# Altium schematic sheet RS232.SchDoc
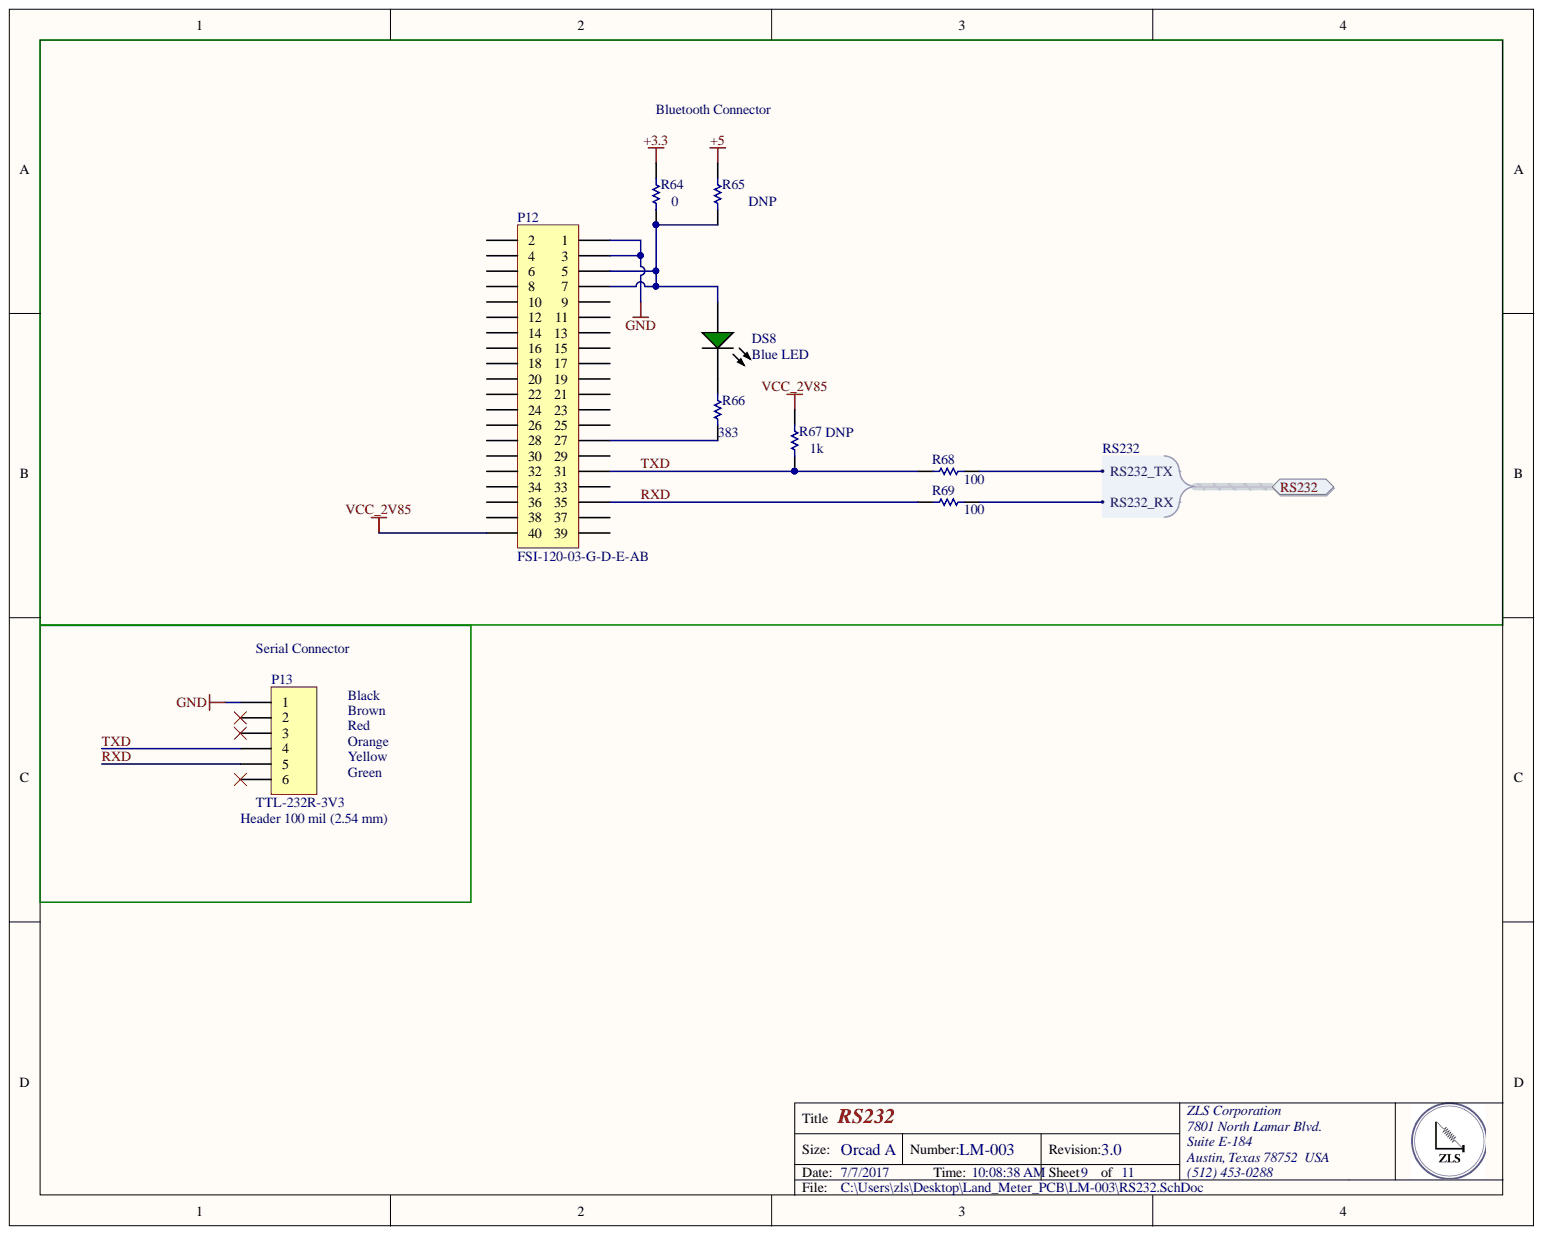
[diagram p0: RS232.SchDoc]

## Parts
DS8  Blue LED  Color: Blue  [KING-LED0603-25-GREEN_V]
P12  FSI-120-03-G-D-E-AB  [FSI-120-03-G-D-E-AB]
P13  Header 100 mil (2.54 mm)  [HDR1X6]
R64  RES SMD 0.0 OHM JUMPER 1/8W 0805  [RESC0805(2012)_M]  (value not drawn)
R65  DNP  [RESC0805(2012)_M]
R66  RES SMD 383 OHM 1% 1/8W 0805  [RESC0805(2012)_M]  (value not drawn)
R67  DNP  Resistance (Ohms): 1k  [RESC0805(2012)_M]
R68  RES SMD 100 OHM 1% 1/8W 0805  [RESC0805(2012)_L]  (value not drawn)
R69  RES SMD 100 OHM 1% 1/8W 0805  [RESC0805(2012)_N]  (value not drawn)

## Ports
RS232, RS232.RS232_RX, RS232.RS232_TX

## Nets
+3.3: R64.2
+5: R65.2
GND: P12.1 P12.3 P13.1
VCC_2V85: P12.40 R67.2
RXD: P12.35 P13.5 R69.2
TXD: P12.31 P13.4 R67.1 R68.2
NetDS8_1: DS8.1 P12.5 P12.7 R64.1 R65.1
NetDS8_2: DS8.2 R66.2
NetP12_27: P12.27 R66.1
NetR68_1: R68.1 ; port RS232
NetR69_1: R69.1 ; port RS232

Not connected: P12 2 4 6 8 9 10 11 12 13 14 15 16 17 18 19 20 21 22 23 24 25 26 28 29 30 32 33 34 36 37 38 39; P13 2 3 6

## Library data of the parts
DS8 = CMP-0239-00001-1: LED 468NM BLUE CLEAR 0603 SMD; Manufacturer 1: Lite-On Inc; Manufacturer Part Number 1: LTST-C191TBKT; Category 1: Optoelectronics; Current - Test: 20mA; Lens Style/Size: Rectangle with Flat Top, 1.1mm x 0.8mm; Millicandela Rating: 30mcd; Viewing Angle: 130°; Voltage - Forward (Vf) (Typ): 3.4V; Wavelength - Dominant: 467.5nm; Component Kind: Standard; ComponentLink1Description: http://optoelectronics.liteon.com/upload/download/DS22-2000-230/S_110_LTST-C191TBKT.pdf; ComponentLink1URL: http://optoelectronics.liteon.com/upload/download/DS22-2000-230/S_110_LTST-C191TBKT.pdf; Description 1: LED 468NM BLUE CLEAR 0603 SMD; Height: 0.55mm; Lens Type: Clear; Library Name: Altium Content Vault; Luminous Flux @ Current - Test: -; Mounting Type: Surface Mount; Package / Case: 0603 (1608 Metric); Packaging: Cut Tape (CT); Pricing 1: 1=0.46, 10=0.342, 100=0.1813, 250=0.12824, 500=0.10944, 1000=0.0855, 2500=0.07524 (USD); RoHS 1: RoHS Compliant; Size / Dimension: 1.60mm L x 0.80mm W; Stock 1: 267074; Supplier 1: Digi-Key; Supplier Part Number 1: 160-1647-1-ND; Wavelength - Peak: 468nm
P12 = Header 20X2: 1MM SINGLE ELEMENT INTERFACE; Manufacturer 1: Samtec Inc.; Manufacturer Part Number 1: FSI-120-03-G-D-M-AB; Category 1: Connectors, Interconnects; Connector Type: Stacking Compression; Number of Positions: 40; Pitch: 0.039" (1.00mm); Component Kind: Standard; ComponentLink1Description: http://suddendocs.samtec.com/prints/fsi-1xx-03-x-d-x-xx-xx-mkt.pdf; ComponentLink1URL: http://suddendocs.samtec.com/prints/fsi-1xx-03-x-d-x-xx-xx-mkt.pdf; Contact Finish: Gold; Contact Finish Thickness: 10µin (0.25µm); Description 1: 1MM SINGLE ELEMENT INTERFACE; Features: Board Guide, Mounting Flange; Height Above Board: 0.118" (3.00mm); Library Name: Miscellaneous Connectors.IntLib; Mated Stacking Heights: -; Mounting Type: Surface Mount; Number of Rows: 2; Packaging: Tube; Part Status: Active; Pricing 1: 1=8.38, 10=7.752, 25=7.3324, 50=6.9554, 100=6.4945, 250=5.65652, 500=5.447, 1000=5.2375, 2500=5.028 (USD); RoHS 1: RoHS Compliant; Stock 1: 144; Supplier 1: Digi-Key; Supplier Part Number 1: SAM9413-ND
P13 = Header 6H: CABLE USB SERIAL 3.3V 2MM HEADER; Manufacturer 1: FTDI, Future Technology Devices International Ltd; Manufacturer Part Number 1: TTL-232R-3V3-2MM; Category 1: Cable Assemblies; Convert From (Adapter End): USB - A, Plug; Convert To (Adapter End): Rectangular Connector, Female Sockets, 8 pos (7 open), 2mm; Shielding: Unshielded; Usage: USB to TTL Serial 3.3V; Component Kind: Standard; ComponentLink1Description: http://www.ftdichip.com/Support/Documents/DataSheets/Cables/DS_TTL-232R_CABLES.pdf; ComponentLink1URL: http://www.ftdichip.com/Support/Documents/DataSheets/Cables/DS_TTL-232R_CABLES.pdf; Description 1: CABLE USB SERIAL 3.3V 2MM HEADER; Length: 5.90' (1.80m); Library Name: Miscellaneous Connectors.IntLib; Part Status: Active; Pricing 1: 1=20, 10=18, 50=16.875 (USD); RoHS 1: RoHS Compliant; Signal Integrity: Connector; Stock 1: 274; Supplier 1: Digi-Key; Supplier Part Number 1: 768-1095-ND
R64 = CMP-1013-00017-1: Manufacturer: Vishay; Manufacturer Part Number: CRCW08050000Z0EA; Max Power Dissipation: 125mW; Resistance: 0; Temperature Coefficient: 100ppm/°C; Tolerance: 1%; Voltage Rating: 150V; Case/Package: 0805; Case Code (Imperial): 0805; Case Code (Metric): 2012; Component Kind: Standard; ComponentLink1Description: https://datasheet.ciiva.com/16883/dcrcwe3-16883622.pdf; ComponentLink1URL: https://datasheet.ciiva.com/16883/dcrcwe3-16883622.pdf; ComponentLink2Description: https://datasheet.ciiva.com/26314/fld-crcw-e3-data-en-26314630.pdf; ComponentLink2URL: https://datasheet.ciiva.com/26314/fld-crcw-e3-data-en-26314630.pdf; ComponentLink3Description: https://datasheet.ciiva.com/5543/dcrcwe3-109170-5543096.pdf; ComponentLink3URL: https://datasheet.ciiva.com/5543/dcrcwe3-109170-5543096.pdf; ComponentLink4Description: https://datasheet.ciiva.com/11811/0900766b80d98b26-11811304.pdf; ComponentLink4URL: https://datasheet.ciiva.com/11811/0900766b80d98b26-11811304.pdf; ComponentLink5Description: https://datasheet.ciiva.com/16389/dcrcwe3-16389338.pdf; ComponentLink5URL: https://datasheet.ciiva.com/16389/dcrcwe3-16389338.pdf; ComponentLink6Description: https://datasheet.ciiva.com/16719/70201119-16719706.pdf; ComponentLink6URL: https://datasheet.ciiva.com/16719/70201119-16719706.pdf; ComponentLink7Description: https://datasheet.ciiva.com/2463/dcrcwe3-2463037.pdf; ComponentLink7URL: https://datasheet.ciiva.com/2463/dcrcwe3-2463037.pdf; ComponentLink8Description: https://datasheet.ciiva.com/7841/1697337-7841629.pdf; ComponentLink8URL: https://datasheet.ciiva.com/7841/1697337-7841629.pdf; ComponentLink9Description: https://datasheet.ciiva.com/2463/dcrcwe3-2463038.pdf; ComponentLink9URL: https://datasheet.ciiva.com/2463/dcrcwe3-2463038.pdf; ComponentLink10Description: https://datasheet.ciiva.com/2463/dcrcwe3-2463039.pdf; ComponentLink10URL: https://datasheet.ciiva.com/2463/dcrcwe3-2463039.pdf; ComponentLink11Description: https://datasheet.ciiva.com/7841/1720486-7841839.pdf; ComponentLink11URL: https://datasheet.ciiva.com/7841/1720486-7841839.pdf; Composition: Thick Film; Contact Plating: Tin,Lead; Depth: 1.25mm; Description: RES SMD 0.0 OHM JUMPER 1/8W 0805; Height: 0.45mm; Lead Free: Lead Free; Length: 2mm; Library Name: Altium Content Vault; Max Operating Temperature: 155°C; Military Standard: Not; Min Operating Temperature: -55°C; Number of Terminations: 2; Package Quantity: 1; Packaging: Tape and Reel; Power Rating: 125mW; Pricing 1: 1=0.1, 10=0.026, 25=0.0184, 50=0.014, 100=0.0104, 250=0.0079, 500=0.0063, 1000=0.0047 (USD); Radiation Hardening: No; REACH SVHC: Unknown; Stock 1: 415251; Supplier 1: Digi-Key; Supplier Part Number 1: 541-0.0ADKR-ND; Termination: SMD/SMT; Voltage Rating (DC): 150V; Weight: 0.000194oz; Width: 1.25mm
R65 = CMP-1013-00017-1: RES SMD 0.0 OHM JUMPER 1/8W 0805; Manufacturer: Vishay; Manufacturer Part Number: CRCW08050000Z0EA; Max Power Dissipation: 125mW; Resistance: 0; Temperature Coefficient: 100ppm/°C; Tolerance: 1%; Voltage Rating: 150V; Case/Package: 0805; Case Code (Imperial): 0805; Case Code (Metric): 2012; Component Kind: Standard; ComponentLink1Description: https://datasheet.ciiva.com/16883/dcrcwe3-16883622.pdf; ComponentLink1URL: https://datasheet.ciiva.com/16883/dcrcwe3-16883622.pdf; ComponentLink2Description: https://datasheet.ciiva.com/26314/fld-crcw-e3-data-en-26314630.pdf; ComponentLink2URL: https://datasheet.ciiva.com/26314/fld-crcw-e3-data-en-26314630.pdf; ComponentLink3Description: https://datasheet.ciiva.com/5543/dcrcwe3-109170-5543096.pdf; ComponentLink3URL: https://datasheet.ciiva.com/5543/dcrcwe3-109170-5543096.pdf; ComponentLink4Description: https://datasheet.ciiva.com/11811/0900766b80d98b26-11811304.pdf; ComponentLink4URL: https://datasheet.ciiva.com/11811/0900766b80d98b26-11811304.pdf; ComponentLink5Description: https://datasheet.ciiva.com/16389/dcrcwe3-16389338.pdf; ComponentLink5URL: https://datasheet.ciiva.com/16389/dcrcwe3-16389338.pdf; ComponentLink6Description: https://datasheet.ciiva.com/16719/70201119-16719706.pdf; ComponentLink6URL: https://datasheet.ciiva.com/16719/70201119-16719706.pdf; ComponentLink7Description: https://datasheet.ciiva.com/2463/dcrcwe3-2463037.pdf; ComponentLink7URL: https://datasheet.ciiva.com/2463/dcrcwe3-2463037.pdf; ComponentLink8Description: https://datasheet.ciiva.com/7841/1697337-7841629.pdf; ComponentLink8URL: https://datasheet.ciiva.com/7841/1697337-7841629.pdf; ComponentLink9Description: https://datasheet.ciiva.com/2463/dcrcwe3-2463038.pdf; ComponentLink9URL: https://datasheet.ciiva.com/2463/dcrcwe3-2463038.pdf; ComponentLink10Description: https://datasheet.ciiva.com/2463/dcrcwe3-2463039.pdf; ComponentLink10URL: https://datasheet.ciiva.com/2463/dcrcwe3-2463039.pdf; ComponentLink11Description: https://datasheet.ciiva.com/7841/1720486-7841839.pdf; ComponentLink11URL: https://datasheet.ciiva.com/7841/1720486-7841839.pdf; Composition: Thick Film; Contact Plating: Tin,Lead; Depth: 1.25mm; Height: 0.45mm; Lead Free: Lead Free; Length: 2mm; Library Name: Altium Content Vault; Max Operating Temperature: 155°C; Military Standard: Not; Min Operating Temperature: -55°C; Number of Terminations: 2; Package Quantity: 1; Packaging: Tape and Reel; Power Rating: 125mW; Pricing 1: 1=0.1, 10=0.026, 25=0.0184, 50=0.014, 100=0.0104, 250=0.0079, 500=0.0063, 1000=0.0047 (USD); Radiation Hardening: No; REACH SVHC: Unknown; Stock 1: 415251; Supplier 1: Digi-Key; Supplier Part Number 1: 541-0.0ADKR-ND; Termination: SMD/SMT; Voltage Rating (DC): 150V; Weight: 0.000194oz; Width: 1.25mm
R66 = CMP-1013-00017-1: Manufacturer: Vishay Dale; Manufacturer Part Number: CRCW0805383RFKEA; Power (Watts): 0.125W, 1/8W; Resistance (Ohms): 383; Temperature Coefficient: ±100ppm/°C; Category: Resistors; Component Kind: Standard; ComponentLink1Description: http://www.vishay.com/docs/20035/dcrcwe3.pdf; ComponentLink1URL: http://www.vishay.com/docs/20035/dcrcwe3.pdf; Composition: Thick Film; Description: RES SMD 383 OHM 1% 1/8W 0805; Failure Rate: -; Features: Automotive AEC-Q200; Height - Seated (Max): 0.020" (0.50mm); Library Name: Altium Content Vault; Operating Temperature: -55°C ~ 155°C; Package / Case: 0805 (2012 Metric); Packaging: Digi-Reel®; Part Status: Active; Pricing 1: 1=0.1, 10=0.044, 100=0.0178, 1000=0.00802, 2500=0.00696 (USD); RoHS: RoHS Compliant; Size / Dimension: 0.079" L x 0.049" W (2.00mm x 1.25mm); Stock 1: 21887; Supplier 1: Digi-Key; Supplier Device Package: 0805; Supplier Part Number 1: 541-383CDKR-ND; Tolerance: ±1%
R67 = CMP-1013-00017-1: RES SMD 1K OHM 1% 1/2W 0805; Manufacturer: Vishay Dale; Manufacturer Part Number: CRCW08051K00FKEAHP; Power (Watts): 0.5W, 1/2W; Temperature Coefficient: ±100ppm/°C; Category: Resistors; Component Kind: Standard; ComponentLink1Description: http://www.vishay.com/docs/20043/crcwhpe3.pdf; ComponentLink1URL: http://www.vishay.com/docs/20043/crcwhpe3.pdf; Composition: Thick Film; Failure Rate: -; Features: Automotive AEC-Q200, Pulse Withstanding; Height - Seated (Max): 0.020" (0.50mm); Library Name: Altium Content Vault; Operating Temperature: -55°C ~ 155°C; Package / Case: 0805 (2012 Metric); Packaging: Digi-Reel®; Part Status: Active; Pricing 1: 1=0.28, 10=0.233, 100=0.0911, 1000=0.03812, 2500=0.03494 (USD); RoHS: RoHS Compliant; Size / Dimension: 0.079" L x 0.049" W (2.00mm x 1.25mm); Stock 1: 64012; Supplier 1: Digi-Key; Supplier Device Package: 0805; Supplier Part Number 1: 541-1.00KTDKR-ND; Tolerance: ±1%
R68 R69 = CMP-1013-00393-1: Manufacturer: Vishay Dale; Manufacturer Part Number: CRCW0805100RFKEA; Power (Watts): 0.125W, 1/8W; Resistance (Ohms): 100; Temperature Coefficient: ±100ppm/°C; Category: Resistors; Component Kind: Standard; ComponentLink1Description: http://www.vishay.com/docs/20035/dcrcwe3.pdf; ComponentLink1URL: http://www.vishay.com/docs/20035/dcrcwe3.pdf; Composition: Thick Film; Description: RES SMD 100 OHM 1% 1/8W 0805; Failure Rate: -; Features: Automotive AEC-Q200; Height - Seated (Max): 0.020" (0.50mm); Library Name: Altium Content Vault; Operating Temperature: -55°C ~ 155°C; Package / Case: 0805 (2012 Metric); Packaging: Digi-Reel®; Part Status: Active; Pricing 1: 1=0.1, 10=0.044, 100=0.0178, 1000=0.00802, 2500=0.00696 (USD); RoHS: RoHS Compliant; Size / Dimension: 0.079" L x 0.049" W (2.00mm x 1.25mm); Stock 1: 281212; Supplier 1: Digi-Key; Supplier Device Package: 0805; Supplier Part Number 1: 541-100CDKR-ND; Tolerance: ±1%
